# Altium schematic sheet mcu.SchDoc
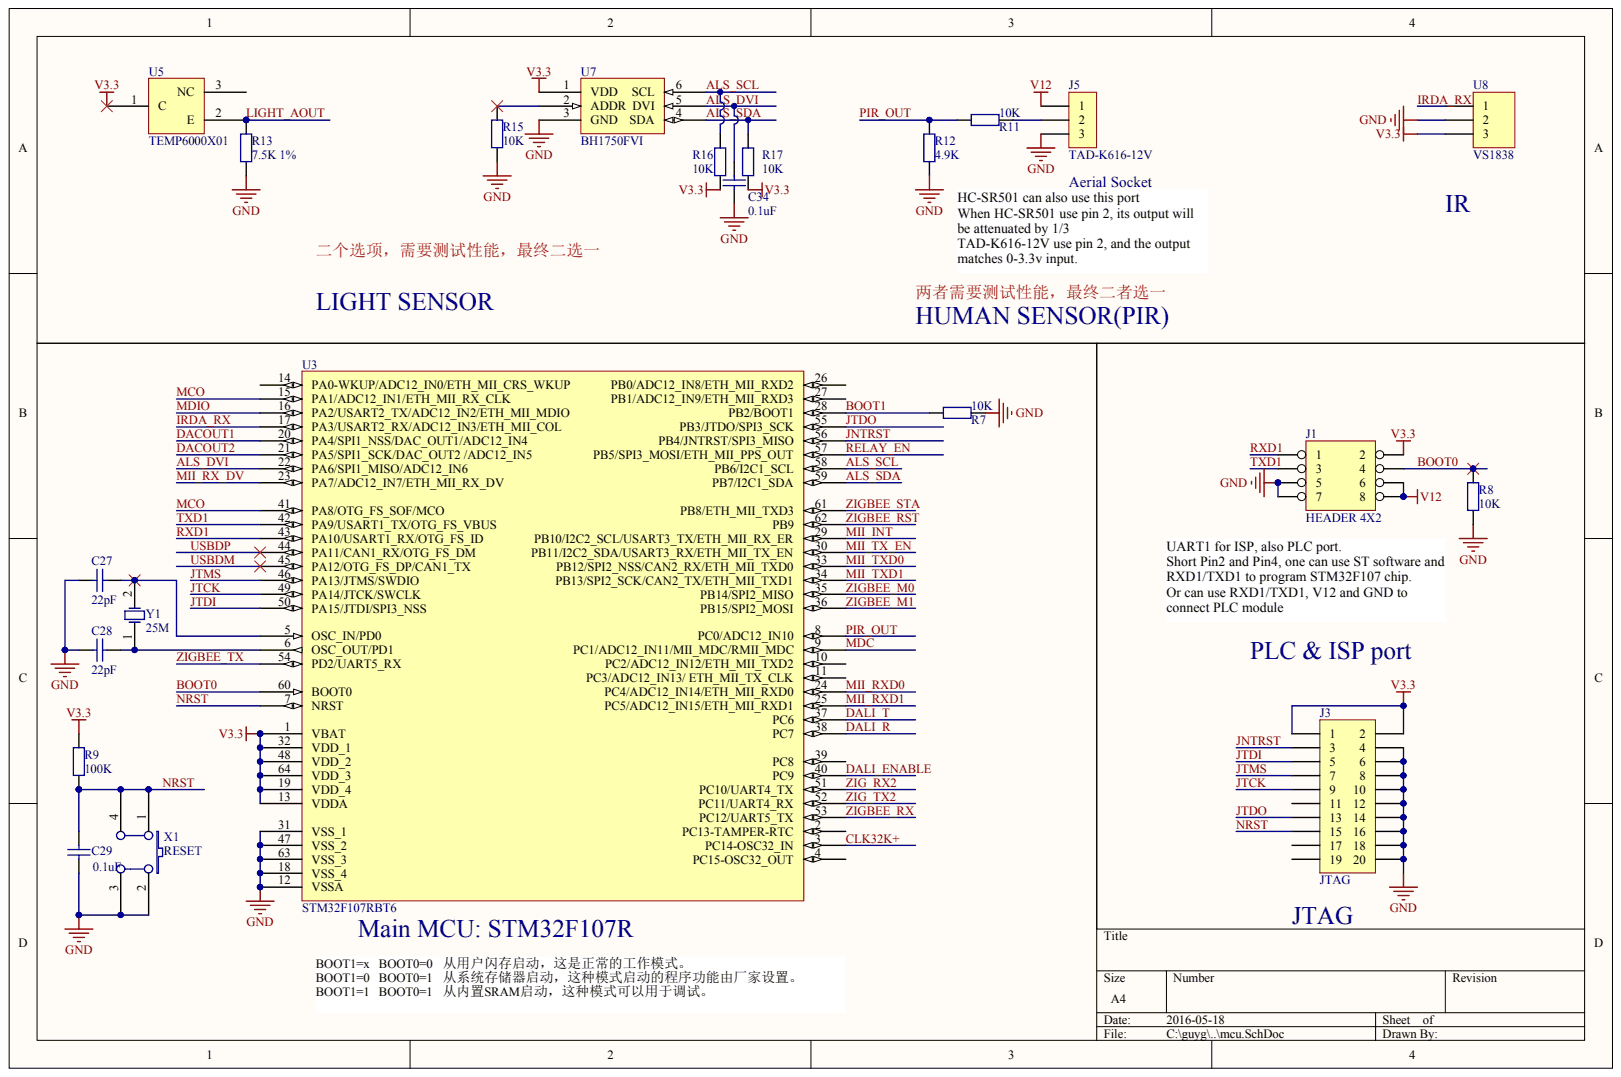
[diagram p0: mcu.SchDoc]

## Parts
C27  22pF
C28  22pF
C29  0.1uF
C34  0.1uF
J1  HEADER 4X2
J3  JTAG
J5  TAD-K616-12V
R7  10K
R8  10K
R9  100K
R11  10K
R12  4.9K
R13  7.5K 1%
R15  10K
R16  10K
R17  10K
U3  STM32F107RBT6
U5  TEMP6000X01
U7  BH1750FVI
U8  VS1838
X1  RESET
Y1  25M

## Nets
GND: C27.1 C28.1 C29.1 C34.2 J1.5 J1.7 J3.4 J3.6 J3.8 J3.10 J3.12 J3.14 J3.16 J3.18 J3.20 J5.3 R7.1 R8.1 R12.1 R13.1 R15.1 U3.12(VSSA) U3.18(VSS_4) U3.31(VSS_1) U3.47(VSS_2) U3.63(VSS_3) U7.3(GND) U8.2 X1.2 X1.3
V3.3: J1.2 J3.1 J3.2 R9.2 R16.1 R17.1 U3.1(VBAT) U3.13(VDDA) U3.19(VDD_4) U3.32(VDD_1) U3.48(VDD_2) U3.64(VDD_3) U5.1 U7.1(VDD) U8.3
V12: J1.6 J1.8 J5.1
ALS_DVI: C34.1 U3.22(PA6/SPI1_MISO/ADC12_IN6) U7.5(DVI)
ALS_SCL: R16.2 U3.58(PB6/I2C1_SCL) U7.6(SCL)
ALS_SDA: R17.2 U3.59(PB7/I2C1_SDA) U7.4(SDA)
BOOT0: J1.4 R8.2 U3.60(BOOT0)
BOOT1: R7.2 U3.28(PB2/BOOT1)
CLK32K+: U3.3(PC14-OSC32_IN)
DACOUT1: U3.20(PA4/SPI1_NSS/DAC_OUT1/ADC12_IN4)
DACOUT2: U3.21(PA5/SPI1_SCK/DAC_OUT2_/ADC12_IN5)
DALI_ENABLE: U3.40(PC9)
DALI_R: U3.38(PC7)
DALI_T: U3.37(PC6)
IRDA_RX: U3.17(PA3/USART2_RX/ADC12_IN3/ETH_MII_COL) U8.1
JNTRST: J3.3 U3.56(PB4/JNTRST/SPI3_MISO)
JTCK: J3.9 U3.49(PA14/JTCK/SWCLK)
JTDI: J3.5 U3.50(PA15/JTDI/SPI3_NSS)
JTDO: J3.13 U3.55(PB3/JTDO/SPI3_SCK)
JTMS: J3.7 U3.46(PA13/JTMS/SWDIO)
LIGHT_AOUT: R13.2 U5.2
MCO: U3.15(PA1/ADC12_IN1/ETH_MII_RX_CLK) U3.41(PA8/OTG_FS_SOF/MCO)
MDC: U3.9(PC1/ADC12_IN11/MII_MDC/RMII_MDC)
MDIO: U3.16(PA2/USART2_TX/ADC12_IN2/ETH_MII_MDIO)
MII_INT: U3.29(PB10/I2C2_SCL/USART3_TX/ETH_MII_RX_ER)
MII_RX_DV: U3.23(PA7/ADC12_IN7/ETH_MII_RX_DV)
MII_RXD0: U3.24(PC4/ADC12_IN14/ETH_MII_RXD0)
MII_RXD1: U3.25(PC5/ADC12_IN15/ETH_MII_RXD1)
MII_TX_EN: U3.30(PB11/I2C2_SDA/USART3_RX/ETH_MII_TX_EN)
MII_TXD0: U3.33(PB12/SPI2_NSS/CAN2_RX/ETH_MII_TXD0)
MII_TXD1: U3.34(PB13/SPI2_SCK/CAN2_TX/ETH_MII_TXD1)
NRST: C29.2 J3.15 R9.1 U3.7(NRST) X1.1 X1.4
PIR_OUT: R11.2 R12.2 U3.8(PC0/ADC12_IN10)
RELAY_EN: U3.57(PB5/SPI3_MOSI/ETH_MII_PPS_OUT)
RXD1: J1.1 U3.43(PA10/USART1_RX/OTG_FS_ID)
TXD1: J1.3 U3.42(PA9/USART1_TX/OTG_FS_VBUS)
USBDM: U3.45(PA12/OTG_FS_DP/CAN1_TX)
USBDP: U3.44(PA11/CAN1_RX/OTG_FS_DM)
ZIG_RX2: U3.51(PC10/UART4_TX)
ZIG_TX2: U3.52(PC11/UART4_RX)
ZIGBEE_M0: U3.35(PB14/SPI2_MISO)
ZIGBEE_M1: U3.36(PB15/SPI2_MOSI)
ZIGBEE_RST: U3.62(PB9)
ZIGBEE_RX: U3.53(PC12/UART5_TX)
ZIGBEE_STA: U3.61(PB8/ETH_MII_TXD3)
ZIGBEE_TX: U3.54(PD2/UART5_RX)
NetC27_2: C27.2 U3.5(OSC_IN/PD0) Y1.2
NetC28_2: C28.2 U3.6(OSC_OUT/PD1) Y1.1
NetJ5_2: J5.2 R11.1
NetR15_2: R15.2 U7.2(ADDR)

Not connected: J3 11 17 19; U3 2(PC13-TAMPER-RTC) 4(PC15-OSC32_OUT) 10(PC2/ADC12_IN12/ETH_MII_TXD2) 11(PC3/ADC12_IN13/_ETH_MII_TX_CLK) 14(PA0-WKUP/ADC12_IN0/ETH_MII_CRS_WKUP) 26(PB0/ADC12_IN8/ETH_MII_RXD2) 27(PB1/ADC12_IN9/ETH_MII_RXD3) 39(PC8); U5 3

## Library data of the parts
R7 R8 R11-R13 R15-R17 = (no symbol name): Value: 1K; Published: 8-Jun-2000; LatestRevisionDate: 17-Jul-2002; LatestRevisionNote: Re-released for DXP Platform.; PackageReference: AXIAL-0.4; Publisher: Altium Limited
R9 = (no symbol name): Value: 10K; Published: 8-Jun-2000; LatestRevisionDate: 17-Jul-2002; LatestRevisionNote: Re-released for DXP Platform.; PackageReference: AXIAL-0.4; Publisher: Altium Limited
C27-C29 C34 J1 J3 J5 U3 U5 U7 U8 X1 Y1 = (no symbol name)
  C27 C28: Published: 8-Jun-2000; LatestRevisionDate: 17-Jul-2002; LatestRevisionNote: Re-released for DXP Platform.; PackageReference: RAD-0.3; Value: 22pF
  C29 C34: Published: 8-Jun-2000; LatestRevisionDate: 17-Jul-2002; LatestRevisionNote: Re-released for DXP Platform.; PackageReference: RAD-0.3; Value: 0.1uF
  J3 U8: LatestRevisionDate: 17-Jul-2002; LatestRevisionNote: Re-released for DXP Platform.
  U3: Published: 30-May-2008; DatasheetVersion: rev6, Mar-2008; PackageVersion: Mar-2008; PackageReference: LQFP64; PackageDescription: 64-Pin Low-Profile Quad Flat Pack, 10 x 10 mm Body, 0.5 mm Pitch (LQFP64); ComponentLink1Description: Manufacturer Link; ComponentLink1URL: www.st.com/stm32; ComponentLink2Description: Datasheet; ComponentLink2URL: http://www.st.com/stonline/products/literature/ds/13587.pdf
  Y1: Published: 8-Jun-2000; LatestRevisionDate: 17-Jul-2002; LatestRevisionNote: Re-released for DXP Platform.; PackageReference: R38
  C27-C29 C34 J3 U3 U8 Y1: Publisher: Altium Limited
